# Altium schematic sheet Power.SchDoc
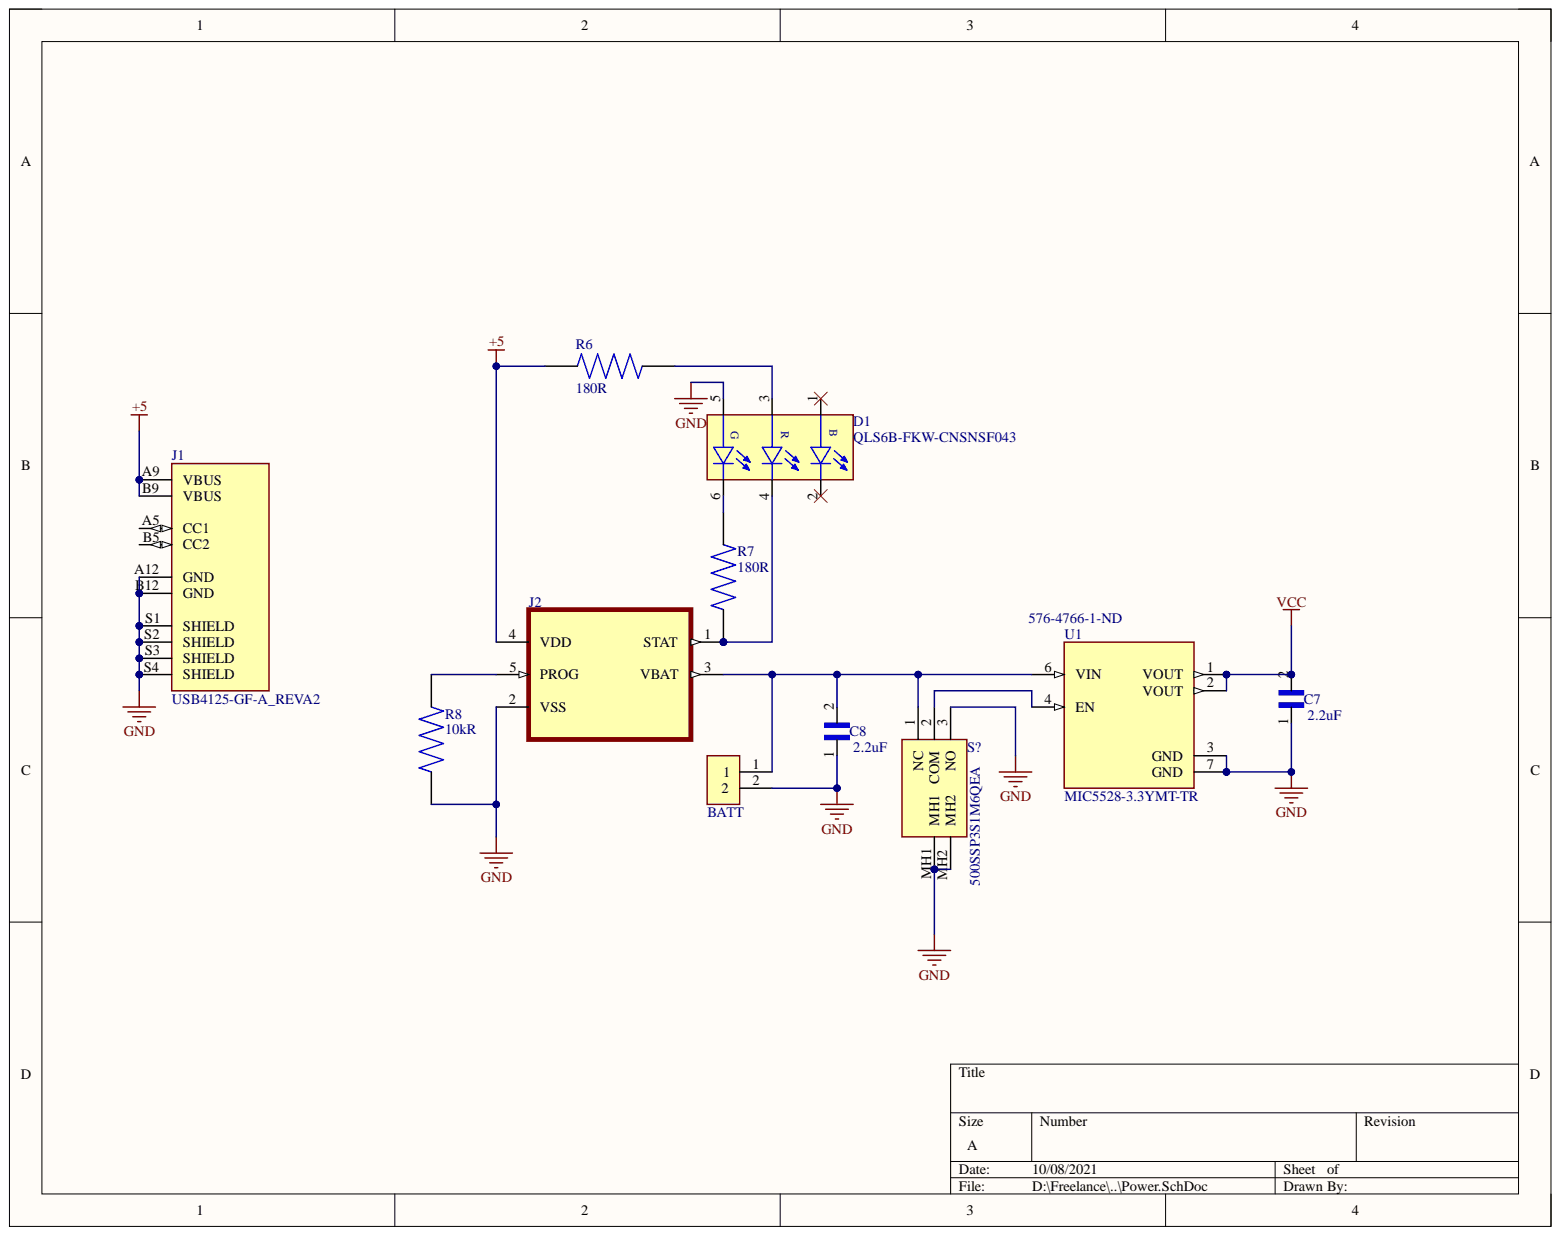
[diagram p0: Power.SchDoc]

## Parts
BATT  B2B-PH-K-S(LF)(SN)  [JST_B2B-PH-K-S(LF)(SN)]  (value not drawn)
C7  2.2uF  [CAPC1608X90N]
C8  2.2uF  [CAPC1608X90N]
D1  QLS6B-FKW-CNSNSF043  [LED_QLS6B-FKW-CNSNSF043]
J1  USB4125-GF-A_REVA2  [GCT_USB4125-GF-A_REVA2]
J2  (no value)  [SOT95P280X145-5N]
R6  180R  [RESC1005X40]
R7  180R  [RESC1005X40]
R8  10kR  [RESC1005X40]
S?  500SSP3S1M6QEA  [500SSP3S1M6QEA]
U1  MIC5528-3.3YMT-TR  Supplier Part Number 1: 576-4766-1-ND  [SON40P120X120X60-7N]

## Nets
+5: J1.A9(VBUS) J1.B9(VBUS) J2.4(VDD) R6.1
GND: BATT.2 C7.1 C8.1 D1.5 J1.A12(GND) J1.B12(GND) J1.S1(SHIELD) J1.S2(SHIELD) J1.S3(SHIELD) J1.S4(SHIELD) J2.2(VSS) R8.2 S?.3(NO) S?.MH1 S?.MH2 U1.3(GND) U1.7(GND)
VCC: C7.2 U1.1(VOUT) U1.2(VOUT)
NetBATT_1: BATT.1 C8.2 J2.3(VBAT) S?.1(NC) U1.6(VIN)
NetD1_3: D1.3 R6.2
NetD1_4: D1.4 J2.1(STAT) R7.1
NetD1_6: D1.6 R7.2
NetJ2_5: J2.5(PROG) R8.1
NetS?_2: S?.2(COM) U1.4(EN)

Not connected: D1 1 2; J1 A5(CC1) B5(CC2)

## Library data of the parts
BATT = B2B-PH-K-S(LF)(SN): Connector Header, PH Series, 2 Position, 2.00mm, Through Hole, Press-Fit, Solder, Natural; Manufacturer: JST; Manufacturer Part Number: B2BPHKSLFSN; Contact Post Length: 0.134inch; Contact Resistance: 20mR; Current Rating: 2A; Gender: Male; Insulation Resistance: 1MR; Mated Stacking Heights: 8mm; Max Voltage Rating (AC): 100V; Number of Contacts: 2; Pitch: 2mm; Category: Connectors; Color: Natural; Component Kind: Standard; ComponentLink1Description: https://datasheet.ciiva.com/6747/80560-6747392.pdf?src-supplier=Newark; ComponentLink1URL: https://datasheet.ciiva.com/6747/80560-6747392.pdf?src-supplier=Newark; ComponentLink2Description: https://datasheet.ciiva.com/11746/0900766b8082f551-11746676.pdf?src-supplier=RSComponents; ComponentLink2URL: https://datasheet.ciiva.com/11746/0900766b8082f551-11746676.pdf?src-supplier=RSComponents; ComponentLink3Description: https://datasheet.ciiva.com/712/eph-712442.pdf?src-supplier=Digi-Key; ComponentLink3URL: https://datasheet.ciiva.com/712/eph-712442.pdf?src-supplier=Digi-Key; ComponentLink4Description: https://datasheet.ciiva.com/8052/80560-8052022.pdf?src-supplier=Element14; ComponentLink4URL: https://datasheet.ciiva.com/8052/80560-8052022.pdf?src-supplier=Element14; ComponentLink5Description: https://datasheet.ciiva.com/16422/b2b-ph-k-slfsn-16422715.pdf?src-supplier=Future+Electronics; ComponentLink5URL: https://datasheet.ciiva.com/16422/b2b-ph-k-slfsn-16422715.pdf?src-supplier=Future+Electronics; Connector Type: Header; Contact Finish - Mating: TIN; Contact Material: Brass; Contact Mating Length: 0.13inch; Contact Plating: Tin,Copper; Contact Shape: Square; Contact Type: Male Pin; Depth: 4.5mm; Fastening Type: Detent; Flammability Rating: UL94 V-0; Height: 9.4mm; Housing Color: White; Housing Material: Polyamide; Insulation Color: Natural; Insulation Height: 0.236inch; Insulation Material: Nylon; Lead Free: Lead Free; Lead Pitch: 2mm; Length: 5.9mm; Library Name: SnapEDA_Library.IntLib; Max Current Rating: 2A; Max Operating Temperature: 85°C; Max Voltage Rating (DC): 100V; Min Operating Temperature: -25°C; Mount: Through Hole; Number of Pins: 2; Number of Poles: 2; Number of Positions: 2; Number of Rows: 1; Orientation: Straight; Packaging: Bulk; REACH SVHC: No SVHC; RoHS: Yes; Series: PH; Supplier 1: Arrow Electronics; Termination: Press-Fit; Voltage Rating: 100V; Voltage Rating (AC): 100V; Width: 4.5mm; Working Voltage: 100V
C7 C8 = CGA3E1X7R0J225K080AC: Cap Ceramic 2.2uF 6.3V X7R 10% SMD 0603 125C Paper T/R; Manufacturer: TDK; Capacitance: 2.2uF; Case Code (Imperial): 0603; Case Code (Metric): 1608; Case/Package: 0603; Category: Ceramic Capacitors; Component Kind: Standard; ComponentLink1Description: https://datasheet.ciiva.com/24219/cga3e1x7r0j225k080ac-265889-24219571.pdf?src-supplier=Mouser; ComponentLink1URL: https://datasheet.ciiva.com/24219/cga3e1x7r0j225k080ac-265889-24219571.pdf?src-supplier=Mouser; ComponentLink2Description: https://datasheet.ciiva.com/28576/1796654-28576764.pdf?src-supplier=Element14; ComponentLink2URL: https://datasheet.ciiva.com/28576/1796654-28576764.pdf?src-supplier=Element14; ComponentLink3Description: https://datasheet.ciiva.com/22718/1796654-22718047.pdf?src-supplier=Element14; ComponentLink3URL: https://datasheet.ciiva.com/22718/1796654-22718047.pdf?src-supplier=Element14; Depth: 0.8mm; Dielectric: X7R; Height: 0.8mm; Lead Free: Lead Free; Length: 1.6mm; Manufacturer Part Number: CGA3E1X7R0J225K080AC; Max Operating Temperature: 125°C; Min Operating Temperature: -55°C; Mount: Surface Mount; Package Quantity: 1; Packaging: Tape and Reel; Pricing 1: 1=0.24000, 10=0.16500, 50=0.09900, 100=0.08360, 500=0.05720, 1000=0.05060 (USD); Ratings: AEC-Q200; REACH SVHC: No SVHC; Resistor Type: Ceramic; RoHS: Yes; RoHS Compliant: Yes; Series: CGA; Stock 1: 294875; Supplier 1: Digi-Key; Termination: SMD/SMT; Thickness: 0.035inch; Tolerance: 10%; Voltage Rating: 6.3V; Voltage Rating (DC): 6.3V; Weight: 7.1E-05oz; Width: 0.031inch
D1 = QLS6B-FKW-CNSNSF043: CREE - QLS6B-FKW-CNSNSF043 - FULL RANK, TAPE&REEL 07AH1280; Color: Blue,Green,Red; Dominant Wavelength: 470nm; Forward Voltage: 3.1V; Lens Size: 3.95mm; Lens Style: Flat; Luminous Intensity: 1850mcd; Test Current: 16mA; Case/Package: SMD/SMT; Category: LEDs; Component Kind: Standard; ComponentLink1Description: http://www.farnell.com/datasheets/2813763.pdf?src-supplier=Farnell; ComponentLink1URL: http://www.farnell.com/datasheets/2813763.pdf?src-supplier=Farnell; ComponentLink2Description: http://www.farnell.com/datasheets/2813763.pdf?src-supplier=Newark; ComponentLink2URL: http://www.farnell.com/datasheets/2813763.pdf?src-supplier=Newark; ComponentLink3Description: https://www.cree.com/led-components/media/documents/1397-QLS6BFKW.pdf?src-supplier=Digi-Key; ComponentLink3URL: https://www.cree.com/led-components/media/documents/1397-QLS6BFKW.pdf?src-supplier=Digi-Key; Height: 1.3mm; Length: 4.45mm; Library Name: SnapEDA_Library.IntLib; Manufacturer: Cree; Manufacturer Part Number: QLS6B-FKW-CNSNSF043; Mount: Surface Mount; Packaging: Tape and Reel; Pricing 1: 1=0.36000, 25=0.33800, 50=0.32500, 100=0.31200 (USD); RoHS: Yes; RoHS Compliant: Yes; Stock 1: 3446; Supplier 1: Avnet; Width: 1.3mm
J1 = USB4125-GF-A_REVA2: MF: {'manufacturer': u'Global Connector Technology'}; Availability: Unavailable; Component Kind: Standard; MP: USB4125-GF-A_REVA2; Package: None; Price: None
J2 = MCP73831T-2ACI/OT: MCP73831 Series Single-Cell Li-Ion/Li-Polymer Battery Charge Controller SOT-23-5; Manufacturer: Microchip; Drain to Source Resistance: 350mR; Max Charge Current: 500mA; Max Input Voltage: 6V; Max Output Voltage: 4.5V; Max Power Dissipation: 1.54W; Min Output Voltage: 4.168V; Min Supply Voltage: 3.75V; Number of Cells: 1; Output Voltage: 4.2V; Voltage Rating: 4.534V; Case/Package: SOT-23; Category: Battery Management; Component Kind: Standard; ComponentLink1Description: https://datasheet.ciiva.com/22828/0900766b80d2b53a-22828876.pdf?src-supplier=RSComponents; ComponentLink1URL: https://datasheet.ciiva.com/22828/0900766b80d2b53a-22828876.pdf?src-supplier=RSComponents; ComponentLink2Description: https://datasheet.ciiva.com/26344/651521-da-01-en-contr-mcp73831t-2aci-ot-sot-23-5-mcp-26344458.pdf?src-supplier=Conrad; ComponentLink2URL: https://datasheet.ciiva.com/26344/651521-da-01-en-contr-mcp73831t-2aci-ot-sot-23-5-mcp-26344458.pdf?src-supplier=Conrad; ComponentLink3Description: https://datasheet.ciiva.com/1931/21984e-1931953.pdf?src-supplier=Digi-Key; ComponentLink3URL: https://datasheet.ciiva.com/1931/21984e-1931953.pdf?src-supplier=Digi-Key; ComponentLink4Description: https://datasheet.ciiva.com/1931/21984e-1931954.pdf?src-supplier=Digi-Key; ComponentLink4URL: https://datasheet.ciiva.com/1931/21984e-1931954.pdf?src-supplier=Digi-Key; ComponentLink5Description: https://datasheet.ciiva.com/1931/21984e-1931955.pdf?src-supplier=Digi-Key; ComponentLink5URL: https://datasheet.ciiva.com/1931/21984e-1931955.pdf?src-supplier=Digi-Key; ComponentLink6Description: https://datasheet.ciiva.com/28626/630369-28626439.pdf?src-supplier=Element14; ComponentLink6URL: https://datasheet.ciiva.com/28626/630369-28626439.pdf?src-supplier=Element14; ComponentLink7Description: https://datasheet.ciiva.com/1137/630369-1137803.pdf?src-supplier=Element14; ComponentLink7URL: https://datasheet.ciiva.com/1137/630369-1137803.pdf?src-supplier=Element14; ComponentLink8Description: https://datasheet.ciiva.com/3213/21984e-3213404.pdf?src-supplier=Avnet; ComponentLink8URL: https://datasheet.ciiva.com/3213/21984e-3213404.pdf?src-supplier=Avnet; ComponentLink9Description: https://datasheet.ciiva.com/46385/630369-46385324.pdf?src-supplier=Element14; ComponentLink9URL: https://datasheet.ciiva.com/46385/630369-46385324.pdf?src-supplier=Element14; ComponentLink10Description: https://datasheet.ciiva.com/1144/630369-1144461.pdf?src-supplier=Newark; ComponentLink10URL: https://datasheet.ciiva.com/1144/630369-1144461.pdf?src-supplier=Newark; ComponentLink11Description: https://datasheet.ciiva.com/16286/filehandler-aspxddocname-en025112-16286957.pdf?src-supplier=Future+Electronics; ComponentLink11URL: https://datasheet.ciiva.com/16286/filehandler-aspxddocname-en025112-16286957.pdf?src-supplier=Future+Electronics; Contact Plating: Tin,Matte; Height: 0.051inch; Lead Free: Lead Free; Length: 0.122inch; Library Name: SnapEDA_Library.IntLib; Manufacturer Part Number: MCP73831T-2ACI/OT; Max Operating Temperature: 85°C; Max Supply Voltage: 6V; Min Operating Temperature: -40°C; Mount: Surface Mount; Number of Channels: 1; Number of Outputs: 1; Number of Pins: 5; Output Current: 500mA; Packaging: Tape and Reel; Pricing 1: 1=0.69000, 25=0.63000 (USD); Radiation Hardening: No; REACH SVHC: No SVHC; RoHS: Yes; RoHS Compliant: Yes; Series: MCP73831/2; Stock 1: 1281; Supplier 1: Digi-Key; Termination: SMD/SMT; Weight: 0.00709oz; Width: 0.071inch
R6 R7 = RMCF0402JT10K0: SMD Chip Resistor, 0402 [1005 Metric], 180 ohm, CRGCQ Series, 50 V, Thick Film, 63 mW; Manufacturer: TE Connectivity; Manufacturer Part Number: CRGCQ0402F180R; Max Power Dissipation: 63mW; Temperature Coefficient: 100ppm/°C; Tolerance: 1%; Case/Package: 0402; Category: Chip SMD Resistors; Component Kind: Standard; ComponentLink1Description: http://www.farnell.com/datasheets/2609223.pdf?src-supplier=Farnell; ComponentLink1URL: http://www.farnell.com/datasheets/2609223.pdf?src-supplier=Farnell; ComponentLink2Description: http://www.te.com/commerce/DocumentDelivery/DDEController?Action=srchrtrv&DocNm=1773204-3&DocType=DS&DocLang=English&src-supplier=Digi-Key; ComponentLink2URL: http://www.te.com/commerce/DocumentDelivery/DDEController?Action=srchrtrv&DocNm=1773204-3&DocType=DS&DocLang=English&src-supplier=Digi-Key; Features: Moisture Resistant,Automotive AEC-Q200; Library Name: SnapEDA_Library.IntLib; Max Operating Temperature: 155°C; Min Operating Temperature: -55°C; Number of Terminations: 2; Packaging: Cut Tape; Pricing 1: 1=0.10000, 10=0.02500, 100=0.01020, 1000=0.00457, 2500=0.00397, 5000=0.00328 (USD); Resistance: 180R; Resistor Type: Thick Film; RoHS: Yes; RoHS Compliant: Yes; Stock 1: 308550; Supplier 1: Digi-Key
R8 = RMCF0402JT10K0: RES 10K OHM 5% 1/16W 0402; Manufacturer: Stackpole Electronics; Max Power Dissipation: 63mW; Power Rating: 62.5mW; Temperature Coefficient: 100ppm/°C; Working Voltage: 50V; Case Code (Imperial): 0402; Case Code (Metric): 1005; Case/Package: 0402; Category: Chip SMD Resistors; Component Kind: Standard; ComponentLink1Description: https://datasheet.ciiva.com/15193/getdatasheetpartid-360689-15193431.pdf?src-supplier=Verical; ComponentLink1URL: https://datasheet.ciiva.com/15193/getdatasheetpartid-360689-15193431.pdf?src-supplier=Verical; Construction: Rectangular; Contact Plating: Tin,Matte; Depth: 0.5mm; Features: Automotive AEC-Q200; Height: 0.016inch; Lead Free: Lead Free; Length: 1mm; Library Name: SnapEDA_Library.IntLib; Manufacturer Part Number: RMCF0402JT10K0; Max Operating Temperature: 155°C; Military Standard: Not; Min Operating Temperature: -55°C; Mount: Surface Mount; Number of Terminations: 2; Packaging: Tape and Reel; Pricing 1: 1=0.10000, 10=0.01200, 100=0.00470, 1000=0.00213, 2500=0.00185, 5000=0.00153 (USD); Radiation Hardening: No; Resistance: 10kR; Resistor Type: Thick Film; RoHS: Yes; RoHS Compliant: Yes; Series: RMCF; Stock 1: 2720859; Supplier 1: Digi-Key; Technology: THICK FILM; Tolerance: 5%; Width: 0.02inch
S? = 500SSP3S1M6QEA: SWITCH SLIDE SPDT 5A 120V; Manufacturer: E-Switch; Actuator Length: 4.72mm; Actuator Material: Nylon,Polyamide; Actuator Type: Standard; Electrical Life: 30000Cycles; Switch Function: On-Off-On; Switch Travel: 2.16mm; Voltage Rating (DC): 28V; Category: Slide Switches; Component Kind: Standard; ComponentLink1Description: https://datasheet.ciiva.com/2890/t511200b-2890395.pdf?src-supplier=Digi-Key; ComponentLink1URL: https://datasheet.ciiva.com/2890/t511200b-2890395.pdf?src-supplier=Digi-Key; Contact Material: Copper Alloy; Contact Plating: Silver; Current Rating: 5A; Manufacturer Part Number: 500SSP3S1M6QEA; Max Operating Temperature: 85°C; Mechanical Life: 30000Cycles; Min Operating Temperature: -30°C; Mount: Through Hole; Package Quantity: 1; Packaging: Bulk; Pricing 1: 1=3.89000, 10=3.72800, 25=3.40440, 100=2.95030, 250=2.67472, 500=2.48020, 1000=2.30189, 2500=2.09116 (USD); RoHS: Yes; Stock 1: 1986; Supplier 1: Digi-Key; Termination: PC Pin; Throw Configuration: SPDT; Voltage Rating (AC): 120V
U1 = MIC5528-3.3YMT-TR: LDO Regulator Pos 3.3V 0.5A 6-Pin TDFN EP T/R; Manufacturer: Microchip; Dropout Voltage: 260mV; Min Current Limit: 525mA; Number of Regulators: 1; Output Current: 500mA; Category: Voltage Regulators - Linear; Component Kind: Standard; ComponentLink1Description: http://www.microchip.com/mymicrochip/filehandler.aspx?ddocname=en580311&src-supplier=Digi-Key; ComponentLink1URL: http://www.microchip.com/mymicrochip/filehandler.aspx?ddocname=en580311&src-supplier=Digi-Key; Manufacturer Part Number: MIC5528-3.3YMT-TR; Max Operating Temperature: 125°C; Min Operating Temperature: -40°C; Mount: Surface Mount; Output Voltage: 3.3V; Packaging: Tape and Reel; Pricing 1: 1=0.23000, 25=0.20160, 100=0.18020 (USD); RoHS: No; Stock 1: 7816; Supplier 1: Digi-Key
